# Altium schematic sheet Flash.SchDoc(Flash)
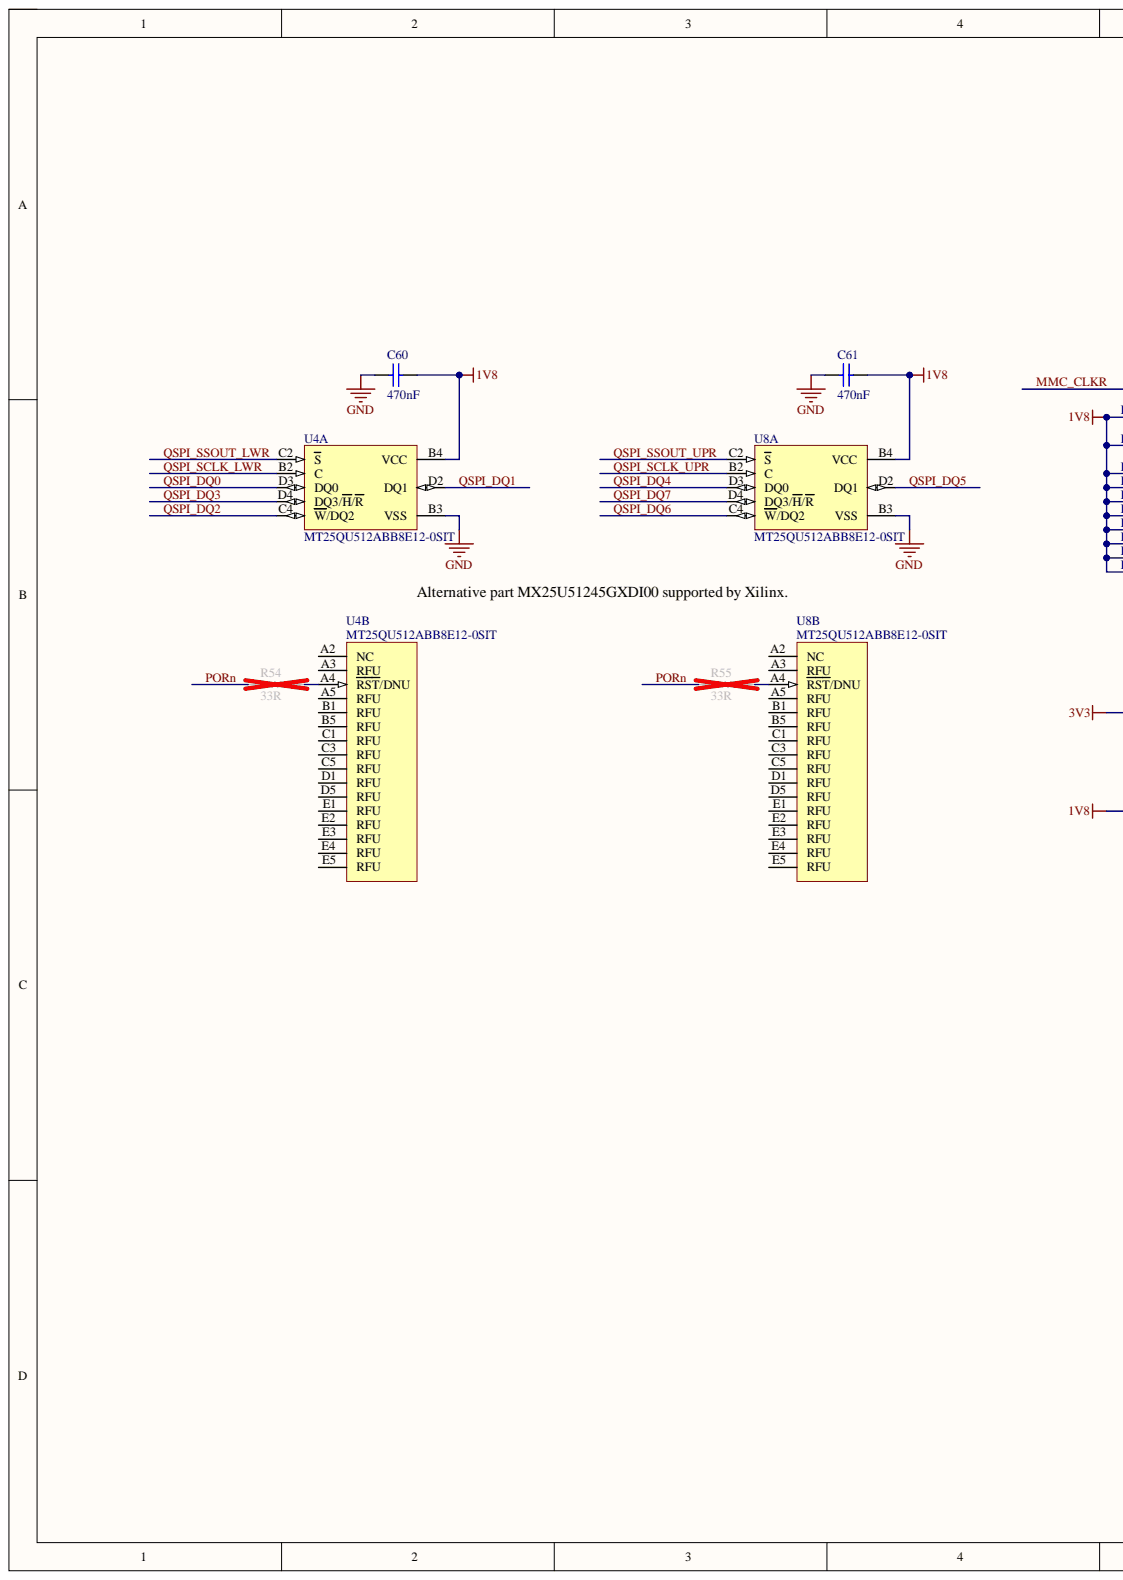
[diagram p0: Flash.SchDoc, left]
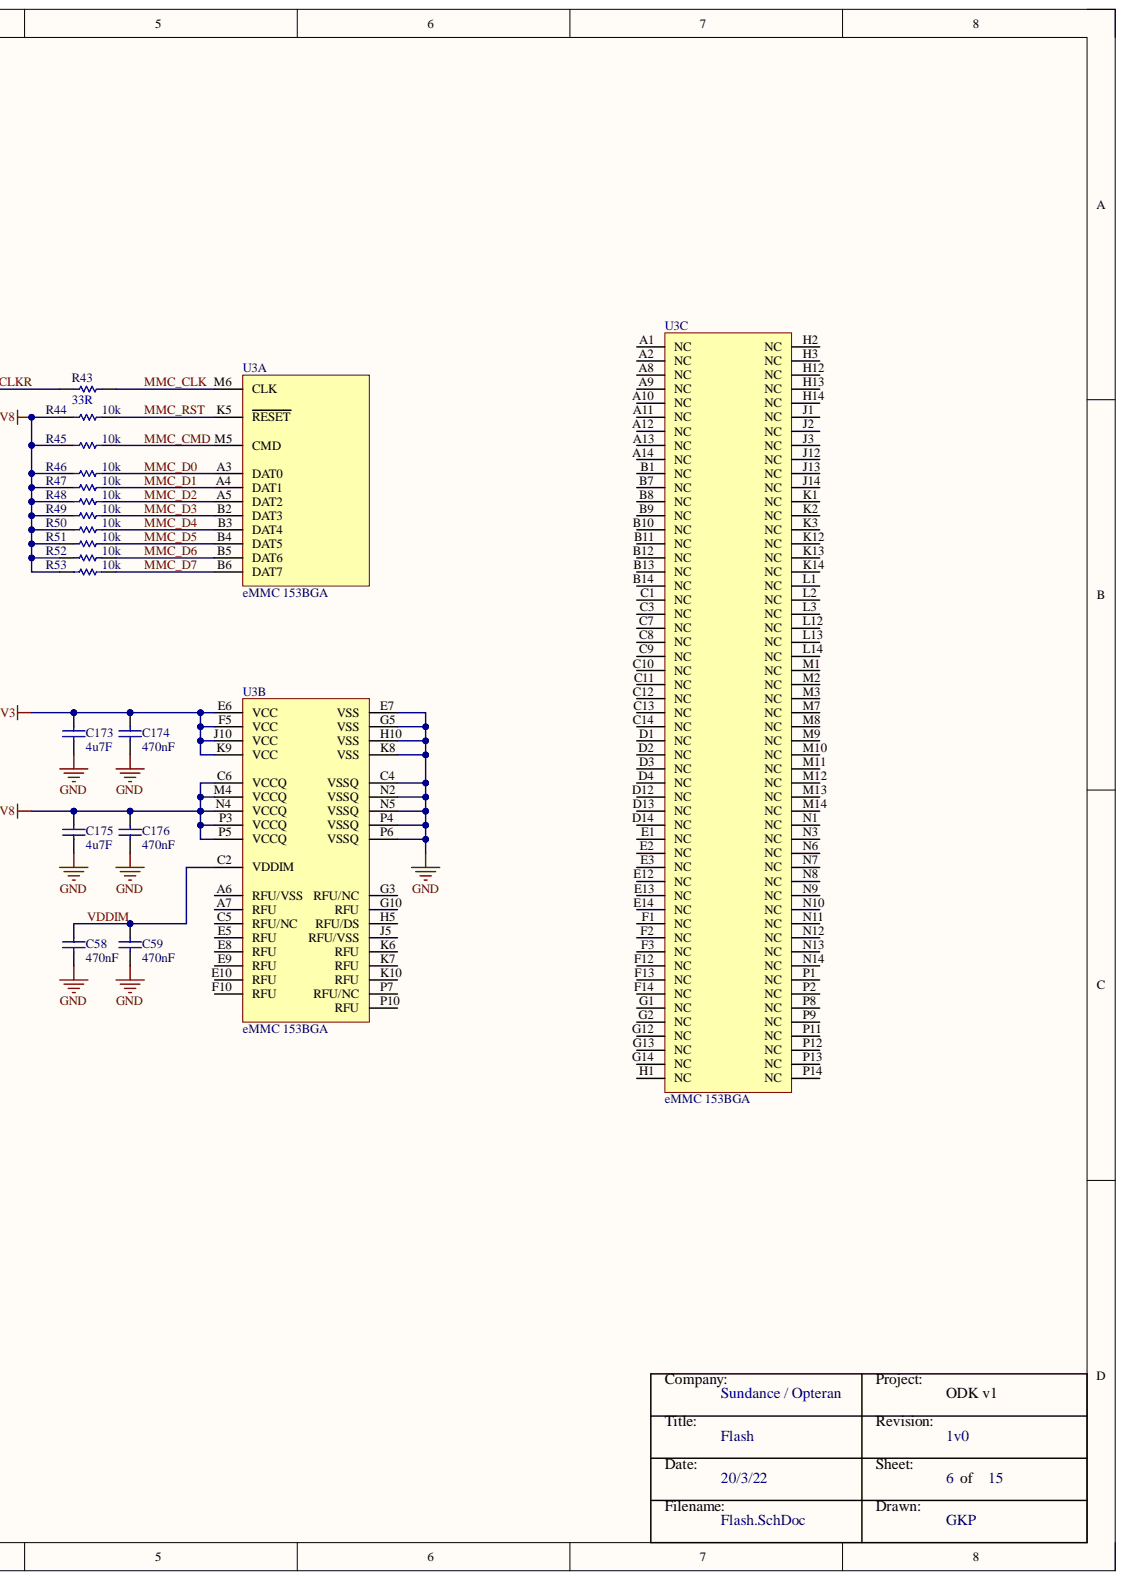
[diagram p1: Flash.SchDoc, right]

## Parts
C58  470nF  [0201panERJ]
C59  470nF  [0201panERJ]
C60  470nF  [0201panERJ]
C61  470nF  [0201panERJ]
C173  4u7F  [0201panERJ]
C174  470nF  [0201panERJ]
C175  4u7F  [0201panERJ]
C176  470nF  [0201panERJ]
R43  33R  [RES0201]
R44  10k  [RES0201]
R45  10k  [RES0201]
R46  10k  [RES0201]
R47  10k  [RES0201]
R48  10k  [RES0201]
R49  10k  [RES0201]
R50  10k  [RES0201]
R51  10k  [RES0201]
R52  10k  [RES0201]
R53  10k  [RES0201]
R54  33R  [RES0201]
R55  33R  [RES0201]
U3  eMMC 153BGA  [eMMC 153L VFBGA 1]  (parts A,B,C)
U4  MT25QU512ABB8E12-0SIT  [BGA24C100P5X5_800X600X120N]  (parts A,B)
U8  MT25QU512ABB8E12-0SIT  [BGA24C100P5X5_800X600X120N]  (parts A,B)

## Nets
1V8: C60.1 C61.1 C175.2 C176.1 R44.2 R45.2 R46.2 R47.2 R48.2 R49.2 R50.2 R51.2 R52.2 R53.2 U3.C6(VCCQ) U3.M4(VCCQ) U3.N4(VCCQ) U3.P3(VCCQ) U3.P5(VCCQ) U4.B4(VCC) U8.B4(VCC)
3V3: C173.2 C174.1 U3.E6(VCC) U3.F5(VCC) U3.J10(VCC) U3.K9(VCC)
GND: C58.2 C59.2 C60.2 C61.2 C173.1 C174.2 C175.1 C176.2 U3.C4(VSSQ) U3.E7(VSS) U3.G5(VSS) U3.H10(VSS) U3.K8(VSS) U3.N2(VSSQ) U3.N5(VSSQ) U3.P4(VSSQ) U3.P6(VSSQ) U4.B3(VSS) U8.B3(VSS)
MMC_CLK: R43.1 U3.M6(CLK)
MMC_CLKR: R43.2
MMC_CMD: R45.1 U3.M5(CMD)
MMC_D0: R46.1 U3.A3(DAT0)
MMC_D1: R47.1 U3.A4(DAT1)
MMC_D2: R48.1 U3.A5(DAT2)
MMC_D3: R49.1 U3.B2(DAT3)
MMC_D4: R50.1 U3.B3(DAT4)
MMC_D5: R51.1 U3.B4(DAT5)
MMC_D6: R52.1 U3.B5(DAT6)
MMC_D7: R53.1 U3.B6(DAT7)
MMC_RST: R44.1 U3.K5(RESET)
PORn: R54.2 R55.2
QSPI_DQ0: U4.D3(DQ0)
QSPI_DQ1: U4.D2(DQ1)
QSPI_DQ2: U4.C4(W/DQ2)
QSPI_DQ3: U4.D4(DQ3/H/R)
QSPI_DQ4: U8.D3(DQ0)
QSPI_DQ5: U8.D2(DQ1)
QSPI_DQ6: U8.C4(W/DQ2)
QSPI_DQ7: U8.D4(DQ3/H/R)
QSPI_SCLK_LWR: U4.B2(C)
QSPI_SCLK_UPR: U8.B2(C)
QSPI_SSOUT_LWR: U4.C2(S)
QSPI_SSOUT_UPR: U8.C2(S)
VDDIM: C58.1 C59.1 U3.C2(VDDIM)
NetR54_1: R54.1 U4.A4(RST/DNU)
NetR55_1: R55.1 U8.A4(RST/DNU)

Not connected: U3 A1(NC) A2(NC) A6(RFU/VSS) A7(RFU) A8(NC) A9(NC) A10(NC) A11(NC) A12(NC) A13(NC) A14(NC) B1(NC) B7(NC) B8(NC) B9(NC) B10(NC) B11(NC) B12(NC) B13(NC) B14(NC) C1(NC) C3(NC) C5(RFU/NC) C7(NC) C8(NC) C9(NC) C10(NC) C11(NC) C12(NC) C13(NC) C14(NC) D1(NC) D2(NC) D3(NC) D4(NC) D12(NC) D13(NC) D14(NC) E1(NC) E2(NC) E3(NC) E5(RFU) E8(RFU) E9(RFU) E10(RFU) E12(NC) E13(NC) E14(NC) F1(NC) F2(NC) F3(NC) F10(RFU) F12(NC) F13(NC) F14(NC) G1(NC) G2(NC) G3(RFU/NC) G10(RFU) G12(NC) G13(NC) G14(NC) H1(NC) H2(NC) H3(NC) H5(RFU/DS) H12(NC) H13(NC) H14(NC) J1(NC) J2(NC) J3(NC) J5(RFU/VSS) J12(NC) J13(NC) J14(NC) K1(NC) K2(NC) K3(NC) K6(RFU) K7(RFU) K10(RFU) K12(NC) K13(NC) K14(NC) L1(NC) L2(NC) L3(NC) L12(NC) L13(NC) L14(NC) M1(NC) M2(NC) M3(NC) M7(NC) M8(NC) M9(NC) M10(NC) M11(NC) M12(NC) M13(NC) M14(NC) N1(NC) N3(NC) N6(NC) N7(NC) N8(NC) N9(NC) N10(NC) N11(NC) N12(NC) N13(NC) N14(NC) P1(NC) P2(NC) P7(RFU/NC) P8(NC) P9(NC) P10(RFU) P11(NC) P12(NC) P13(NC) P14(NC); U4 A2(NC) A3(RFU) A5(RFU) B1(RFU) B5(RFU) C1(RFU) C3(RFU) C5(RFU) D1(RFU) D5(RFU) E1(RFU) E2(RFU) E3(RFU) E4(RFU) E5(RFU); U8 A2(NC) A3(RFU) A5(RFU) B1(RFU) B5(RFU) C1(RFU) C3(RFU) C5(RFU) D1(RFU) D5(RFU) E1(RFU) E2(RFU) E3(RFU) E4(RFU) E5(RFU)

## Library data of the parts
C58-C61 C174 C176 = Cap0201: 470nF 0201 6V3 X5R; Case-EIA: 0402; Component Kind: Standard; Library Name: Schematic Components.SchLib; Max Thickness: 0.6 mm; Technology: SMT
C173 C175 = Cap0201: 4u7F 0201 6V3 X5R; Case-EIA: 0402; Component Kind: Standard; Library Name: Schematic Components.SchLib; Max Thickness: 0.6 mm; Technology: SMT
R43 R54 R55 = Res0201: 33R 0201 1/16W 1%; Value: ?k; Component Kind: Standard; Library Name: Schematic Components.SchLib
R44-R53 = Res0201: 10k 0201 1/16W 1%; Value: ?k; Component Kind: Standard; Library Name: Schematic Components.SchLib
U3 = eMMC 153BGA: FLASH - NAND (MLC) Memory IC 64Gb (8G x 8) eMMC 200 MHz 153-VFBGA (11.5x13); Manufacturer 1: Integrated Silicon Solution Inc; Manufacturer Part Number 1: IS21ES08G-JCLI-TR; Component Kind: Standard; Library Name: Schematic Components.SchLib; Manufacturer 2: Flexxon Pte Ltd; Manufacturer Part Number 2: FEMC008GBG-T340; Supplier 1: Digikey; Supplier 2: Digikey; Supplier Part Number 1: IS21ES08G-JCLI-TR-ND; Supplier Part Number 2: 3052-FEMC008GBG-T340-ND
U4 U8 = MT25QU512ABB8E12-0SIT: FLASH - NOR Memory IC 512Mb (64M x 8) SPI 133 MHz 24-T-PBGA (6x8); Manufacturer 1: Micron Technology Inc.; Component Kind: Standard; Library Name: Schematic Components.SchLib; Manufacturer 2: Macronix; Manufacturer Part Number 1: MT25QU512ABB8E12-0SIT; Manufacturer Part Number 2: MX25U51245GXDI00; Supplier 1: Digi-Key; Supplier 2: Digikey; Supplier Part Number 1: MT25QU512ABB8E12-0SIT-ND; Supplier Part Number 2: 1092-1250-ND
